# Altium schematic sheet 4. Power  Supply.SchDoc
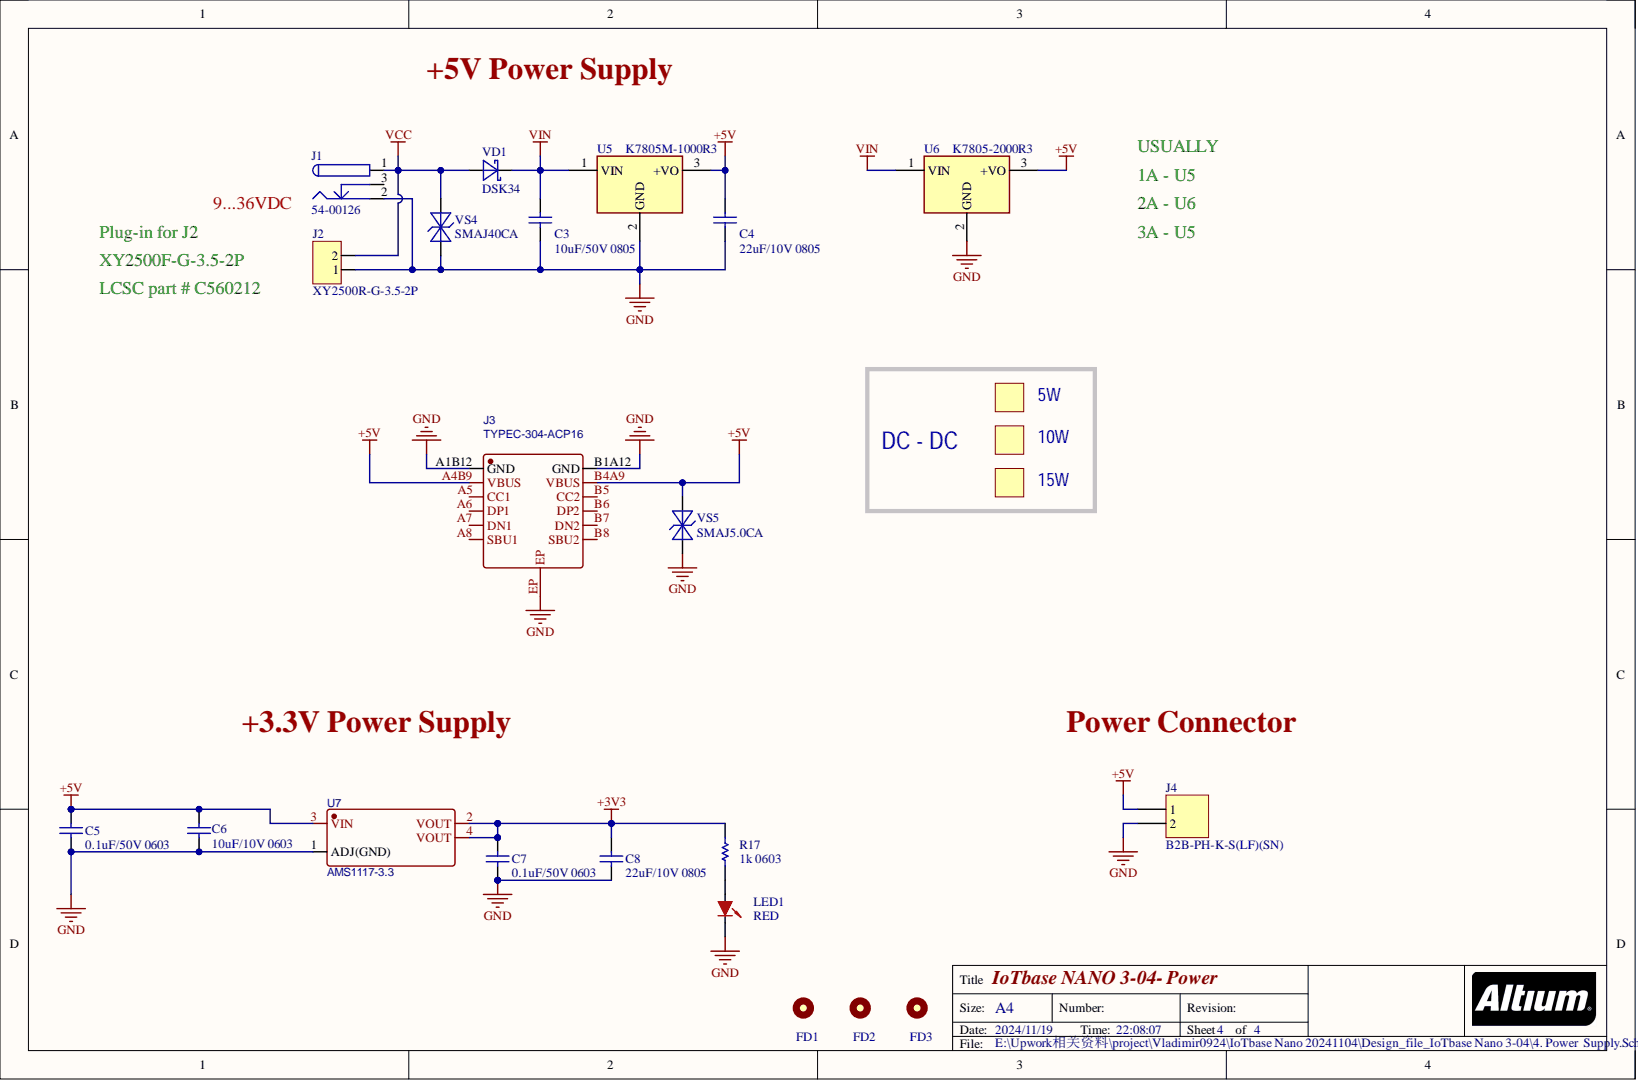
[diagram p0: 4. Power  Supply.SchDoc]

## Parts
C3  10uF/50V 0805  [CAPC2012X110N]
C4  22uF/10V 0805  [CAPC2012X110N]
C5  0.1uF/50V 0603  [CAPC1608X100N]
C6  10uF/10V 0603  [CAPC1608X100N]
C7  0.1uF/50V 0603  [CAPC1608X100N]
C8  22uF/10V 0805  [CAPC2012X110N]
FD1  FIDUCIAL_MARK  [FIDUCIAL_MARK_1MM]  (value not drawn)  (no BOM)
FD2  FIDUCIAL_MARK  [FIDUCIAL_MARK_1MM]  (value not drawn)  (no BOM)
FD3  FIDUCIAL_MARK  [FIDUCIAL_MARK_1MM]  (value not drawn)  (no BOM)
J1  54-00126  [Conn_54-00126]
J2  XY2500R-G-3.5-2P  [CON_1X02_3.5MM_MALE_RA]
J3  TYPEC-304-ACP16  [USB-C-SMD_TYPE-C-16P-1]
J4  B2B-PH-K-S(LF)(SN)  [JST_B2B-PH-K-S(LF)(SN)_1]
LED1  RED  [LEDC1608X80N_RED]
R17  1k 0603  [RESC1608X50N]
U5  K7805M-1000R3  [CONV_K7805M-1000R3]
U6  K7805-2000R3  [CONV_K7805-2000R3]
U7  AMS1117-3.3  [SOT-223-3_L6.5-W3.4-P2.30-LS7.0-BR]
VD1  DSK34  [SOD-123]
VS4  SMAJ40CA  [SMAJ_BI]
VS5  SMAJ5.0CA  [SMAJ_BI]

## Nets
+3V3: C7.2 C8.2 R17.1 U7.2(VOUT) U7.4(VOUT)
+5V: C4.2 C5.2 C6.2 J3.A4B9(VBUS) J3.B4A9(VBUS) J4.1 U5.3 U6.3 U7.3(VIN) VS5.2
GND: C3.1 C4.1 C5.1 C6.1 C7.1 C8.1 J1.2 J2.1 J3.A1B12(GND) J3.B1A12(GND) J3.EP J4.2 LED1.2 U5.2 U6.2 U7.1(ADJ(GND)) VS4.1 VS5.1
VCC: J1.1 J2.2 VD1.1 VS4.2
VIN: C3.2 U5.1 U6.1 VD1.2
NetLED1_1: LED1.1 R17.2

Not connected: FD1 1; FD2 1; FD3 1; J1 3; J3 A5(CC1) A6(DP1) A7(DN1) A8(SBU1) B5(CC2) B6(DP2) B7(DN2) B8(SBU2)

## Library data of the parts
C3 = Cap: 50V 10uF X5R ±10% 0805 Multilayer Ceramic Capacitors MLCC - SMD/SMT ROHS; Manufacturer: Samsung; Manufacturer Part Number: CL21A106KBYQNNE; Component Kind: Standard; Library Name: Miscellaneous Devices.IntLib; Signal Integrity: Cap; Simulation: CAP; Supplier 1: LCSC; Supplier Part Number 1: C2932476; Value: 10uF/50V 0805
C4 C8 = Cap: 10V 22uF X5R ±10% 0805 Multilayer Ceramic Capacitors MLCC - SMD/SMT ROHS; Manufacturer: Samsung Electro-Mechanics; Manufacturer Part Number: CL21A226KPCLRNC; Component Kind: Standard; Library Name: Miscellaneous Devices.IntLib; Signal Integrity: Cap; Simulation: CAP; Supplier 1: LCSC; Supplier Part Number 1: C318688; Value: 22uF/10V 0805
C5 C7 = Cap: 50V 100nF X7R ±10% 0603 Multilayer Ceramic Capacitors MLCC - SMD/SMT ROHS; Manufacturer: Walsin Tech Corp; Manufacturer Part Number: 0603B104K500CT; Component Kind: Standard; Library Name: Miscellaneous Devices.IntLib; Signal Integrity: Cap; Simulation: CAP; Supplier 1: LCSC; Supplier Part Number 1: C314282; Value: 0.1uF/50V 0603
C6 = Cap: 10V 10uF X5R ±10% 0603 Multilayer Ceramic Capacitors MLCC - SMD/SMT ROHS; Manufacturer: Samsung Electro-Mechanics; Manufacturer Part Number: CL10A106KP8NNNC; Component Kind: Standard; Library Name: Miscellaneous Devices.IntLib; Signal Integrity: Cap; Simulation: CAP; Supplier 1: LCSC; Supplier Part Number 1: C19702; Value: 10uF/10V 0603
FD1-FD3 = FIDUCIAL_MARK: Checked_By: Dodig; Drawn_By: Puskar; BL Part Number: N/A; DateModified: 17-06-2013; Description: FIDUCIAL_MARK; Library Name: Schematic_Lib.SchLib; Manufacturer: N/A; Manufacturer Part Number: N/A
J1 = DC_JACK_54-00126: CONN JACK R/A PCB 3.5X1.35MM; Manufacturer: Tensility; Component Kind: Standard; Library Name: Schematic_Lib.SchLib; Manufacturer Part Number: 54-00126; Supplier 1: Digi-Key; Supplier Part Number 1: 839-1509-ND
J2 = CON_1X02_3.5MM_MALE_RA: 300V 8A 2 Bend 1 3.5mm 1x2P Green Board Edge/Receptacle-Close Push-Pull,P=3.5mm Pluggable System Terminal Block ROHS; Manufacturer: Ningbo Xinlaiya Elec.; Component Kind: Standard; Library Name: Schematic_Lib.SchLib; Manufacturer Part Number: XY2500R-G-3.5-2P; Supplier 1: LCSC; Supplier Part Number 1: C880572; Value: XY2500R-G-3.5-2P
J3 = TYPEC-304-ACP16: 3A 1 Surface Mount 16P Female -40℃~+85℃ Gold Copper Type-C SMD USB Connectors ROHS; BOM_Manufacturer: XUNPU(讯普); Manufacturer: XUNPU; Contributor: LCSC; BOM_JLCPCB Part Class: Extended Part; BOM_Manufacturer Part: TYPEC-304-ACP16; BOM_Supplier: LCSC; BOM_Supplier Part: C2982555; Component Kind: Standard; Manufacturer Part Number: TYPEC-304-ACP16; spicePre: U; spiceSymbolName: TYPEC-304-ACP16; Supplier 1: LCSC; Supplier Part Number 1: C2982555; Library Name: IoTextra_Input_2-03.SCHLIB
J4 = Header 2: 1x2P PH 1 2mm 2 Brass Plugin,P=2mm Wire To Board / Wire To Wire Connector ROHS; Manufacturer: JST Sales America; Component Kind: Standard; Library Name: Miscellaneous Connectors.IntLib; Manufacturer Part Number: B2B-PH-K-S(LF)(SN); Signal Integrity: Connector; Supplier 1: LCSC; Supplier Part Number 1: C131337
LED1 = LED SMD 0402  RED 150040RS73240: -40℃~+85℃ Red 0603 Light Emitting Diodes (LED) ROHS; Manufacturer: Hubei KENTO Elec; Manufacturer Part Number: KT-0603R; Component Kind: Standard; Library Name: LED.SchLib; Supplier 1: LCSC; Supplier Part Number 1: C2286
R17 = Res1: 100mW Thick Film Resistors ±100ppm/℃ ±1% 1kΩ 0603 Chip Resistor - Surface Mount ROHS; Manufacturer: UNI-ROYAL(Uniroyal Elec); Manufacturer Part Number: 0603WAF1001T5E; Component Kind: Standard; Library Name: Miscellaneous Devices.IntLib; Supplier 1: LCSC; Supplier Part Number 1: C21190; Value: 1k 0603
U5 = K7805-2000R3: 5V 1000mA 7V~36V 5W DC-DC 94% SIP-3 Power Modules ROHS; Manufacturer: YLPTEC; Component Kind: Standard; Manufacturer Part Number: K7805M-1000R3; Supplier 1: LCSC; Supplier Part Number 1: C5369649; Library Name: IoTbase B+ 3-01.SCHLIB
U6 = K7805-2000R3: 5V 2A 8V~36V 10W 92% Plugin Power Modules ROHS; Manufacturer: MORNSUN Guangzhou S& T; Component Kind: Standard; Manufacturer Part Number: K7805-2000R3; Supplier 1: LCSC; Supplier Part Number 1: C970791; Library Name: IoTbase B+ 3-01.SCHLIB
U7 = AMS1117-3.3_C2891839: SOT-223 Linear Voltage Regulators (LDO) ROHS; Manufacturer: GUOXIN JIAPIN SEMICONDUCTOR; Component Kind: Standard; Library Name: RP2040TR7.SchLib; Manufacturer Part Number: AMS1117-3.3; Supplier 1: LCSC; Supplier Part Number 1: C2992570
VD1 = DSK34: 40V Single 3A 550mV@3A SOD-123 Schottky Barrier Diodes (SBD) ROHS; Manufacturer: KUU; Component Kind: Standard; Manufacturer Part Number: DSK34; Supplier 1: LCSC; Supplier Part Number 1: C3015221; Value: DSK34; Library Name: IoTextra_Input_2-03.SCHLIB
VS4 = SMAJxxCA: 64.5V 44.4V 40V SMA(DO-214AC) TVS ROHS; Manufacturer: BORN; Component Kind: Standard; Manufacturer Part Number: SMAJ40CA; PartNumber1: SMAJ40CA; Supplier 1: LCSC; Supplier Part Number 1: C151933; Value: SMAJ40CA; Library Name: IoTextra_Input_2-03.SCHLIB
VS5 = SMAJxxCA: 9.2V 6.4V 5V SMA(DO-214AC) TVS ROHS; Manufacturer: GOODWORK; Component Kind: Standard; Manufacturer Part Number: SMAJ5.0CA; PartNumber1: SMAJ5.0CA; Supplier 1: LCSC; Supplier Part Number 1: C908809; Value: SMAJ5.0CA; Library Name: IoTextra_Input_2-03.SCHLIB
